# Altium schematic sheet serial.SchDoc
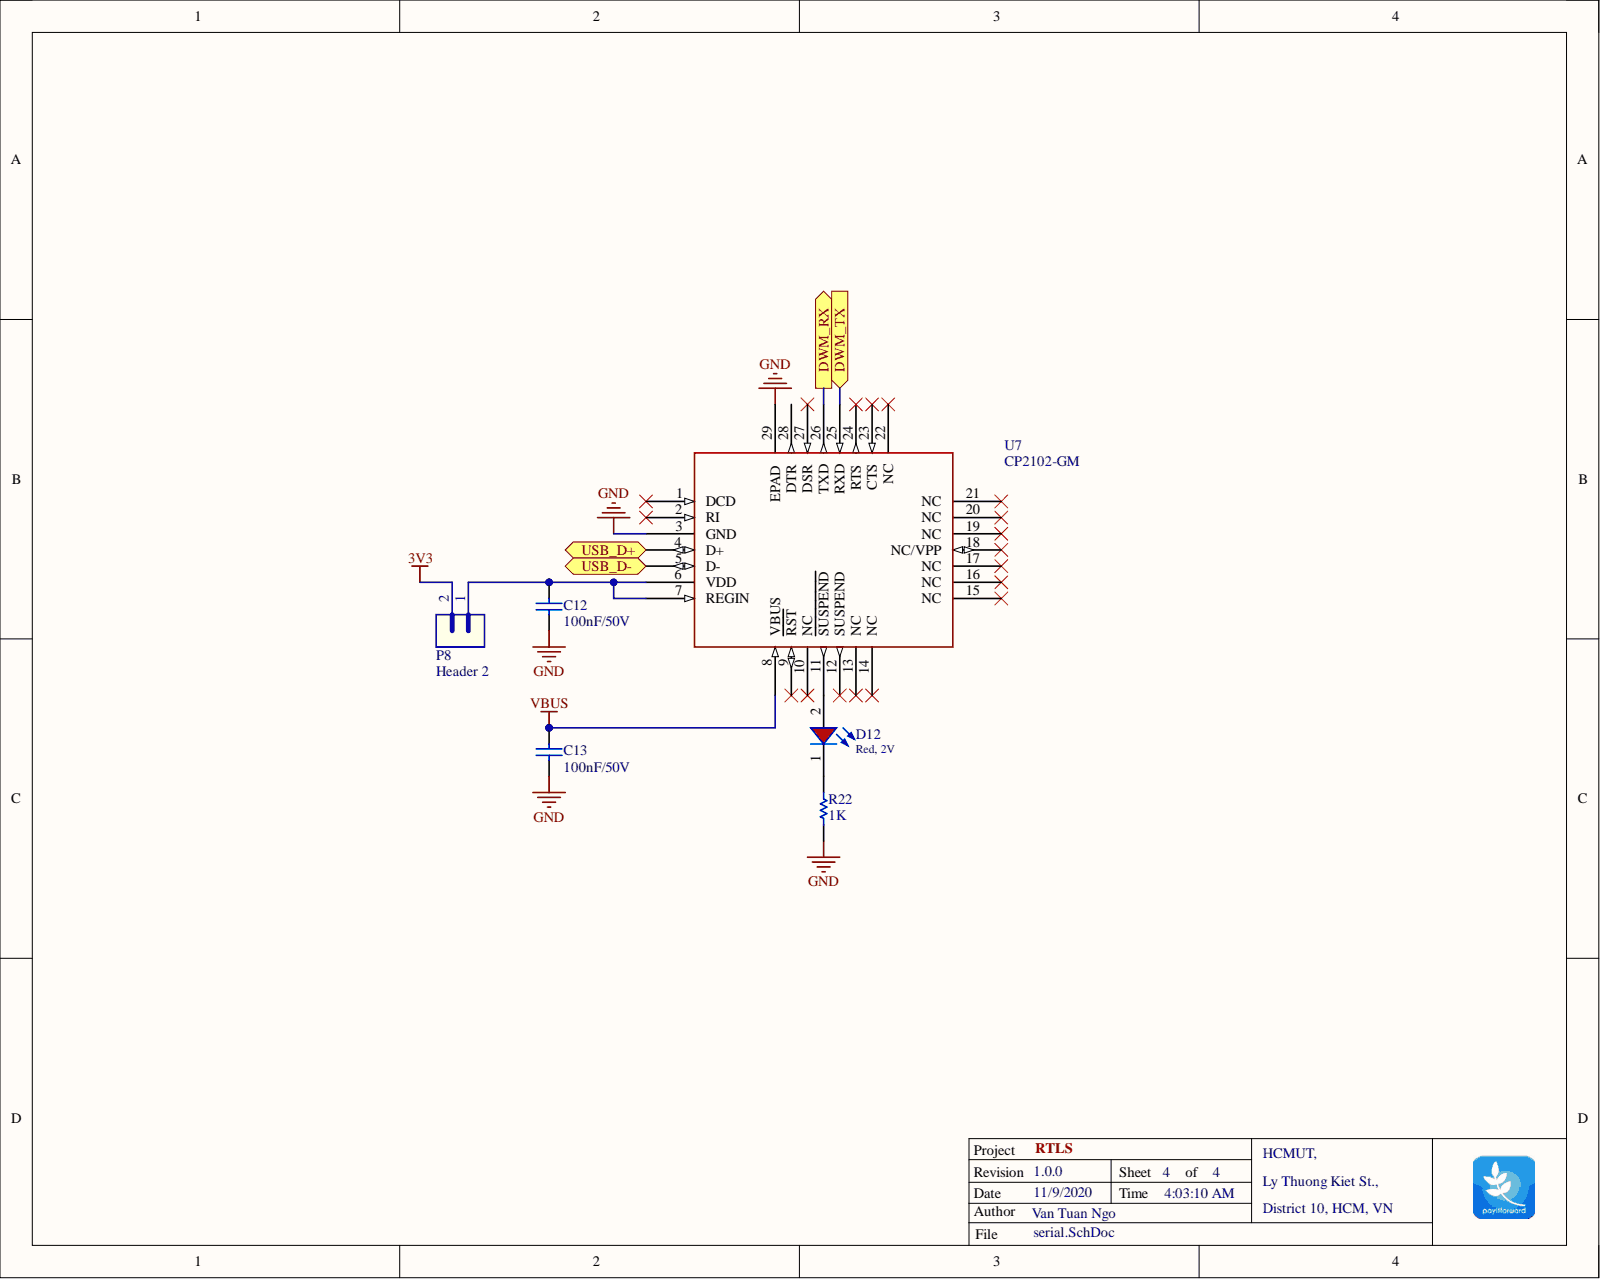
[diagram p0: serial.SchDoc]

## Parts
C12  value 100nF/50V  [CAP-SM-0805]  (hidden comment: Cap-100nF-50V-0805)
C13  value 100nF/50V  [CAP-SM-0805]  (hidden comment: Cap-100nF-50V-0805)
D12  Red, 2V  [WL-SMCW_0805]
P8  Header 2  [JP2]
R22  value 1K  [RES-SM-0805]  (hidden comment: Res-1K-0805)
U7  CP2102-GM  [QFN28_5X5_SIL]

## Ports
DWM_RX, DWM_TX, USB_D+, USB_D-

## Nets
3V3: P8.2
GND: C12.1 C13.1 R22.1 U7.3(GND) U7.29(EPAD)
VBUS: C13.2 U7.8(VBUS)
DWM_RX: U7.26(TXD) ; port DWM_RX
DWM_TX: U7.25(RXD) ; port DWM_TX
NetC12_2: C12.2 P8.1 U7.6(VDD) U7.7(REGIN)
NetD12_1: D12.1 R22.2
NetD12_2: D12.2 U7.11(SUSPEND)
NetU7_4: U7.4(D+)
NetU7_5: U7.5(D-)

Not connected: U7 1(DCD) 2(RI) 9(RST) 10(NC) 12(SUSPEND) 13(NC) 14(NC) 15(NC) 16(NC) 17(NC) 18(NC/VPP) 19(NC) 20(NC) 21(NC) 22(NC) 23(CTS) 24(RTS) 27(DSR) 28(DTR)

## Library data of the parts
C12 C13 = Cap-100nF-50V-0805: CAP CER 0.1UF 50V Y5V 0805; Manufacturer: Samsung Electro-Mechanics; Manufacturer Part Number: CL21F104ZBCNNNC; Component Kind: Standard; Library Name: Capacitor.SchLib; Local Supplier: thegioiic; Local Supplier Link: https://thegioiic.com/products/tu-100nf-0-1uf-50v-0805; Supplier 1: Digikey; Supplier 1 Link: https://www.digikey.com/product-detail/en/samsung-electro-mechanics/CL21F104ZBCNNNC/1276-1007-1-ND/3889093; Supplier 1 Part Number: 1276-1007-1-ND
D12 = 150080RS75000: WL-SMCW SMT Mono-color Chip LED Waterclear, size 0805, Red, 2V, 140deg; Chip Technology: AlInGaP; Dominant Wavelength typ.: 625nm; Luminous Intensity typ.: 150mcd; Peak Wavelength typ.: 630nm; Component Kind: Standard; ComponentLink1Description: Manufacturer; ComponentLink1URL: http://www.we-online.de; ComponentLink2Description: Datasheet; ComponentLink2URL: https://www.we-online.com/catalog/datasheet/150080RS75000.pdf; Emitting Color: Red; Forward Voltage typ.: 2V; Library Name: led-smd-0805.SchLib; Mounting Technology: SMT; Operating Temperature: -40 to +85degC; Packaging: Tape and Reel; Part Number: 150080RS75000; Published Date: December 2019; Size: 0805; Viewing Angle: 140deg; Publisher: Wurth Elektronik
P8 = Header 2: Header, 2-Pin; Component Kind: Standard; LatestRevisionDate: 17-Jul-2002; LatestRevisionNote: Re-released for DXP Platform.; Library Name: header-connector.SchLib; Local Supplier: thegioiic; Local Supplier Link: https://www.thegioiic.com/products/hang-rao-duc-don-2-54mm-40-chan-1-hang-cao-11-4mm-xuyen-lo; Local Supplier Part Number: x; Publisher: Altium Limited
R22 = Res-1K-0805: RES 1K OHM 1% 1/4W 0805; Manufacturer: Stackpole Electronics Inc; Manufacturer Part Number: RNCP0805FTD1K00; Component Kind: Standard; Library Name: resistor-smd.SchLib; Local Supplier: thegioiic; Local Supplier Link: https://www.thegioiic.com/products/dien-tro-1-kohm-0805-5; Supplier 1: Digikey; Supplier 1 Link: https://www.digikey.com/product-detail/en/stackpole-electronics-inc/RNCP0805FTD1K00/RNCP0805FTD1K00CT-ND/2240568; Supplier 1 Part Number: RNCP0805FTD1K00CT-ND
U7 = CP2102-GM: Built_By: EMA_UL_Team; SourceLibrary: Silicon_Labs_2015-03-23; Vendor: Silicon Labs; Component Kind: Standard; Local Supplier: thegioiic; Local Supplier Link: https://www.thegioiic.com/products/cp2102; Manufacturer_Part_Number: CP2102-GM; RefDes: RefDes; Type: DEV; Copyright: Copyright (C) 2018 Accelerated Designs. All rights reserved; Library Name: functional-ic.SchLib
